# Altium schematic sheet B2B_Connector.SchDoc(B2B_Connector)
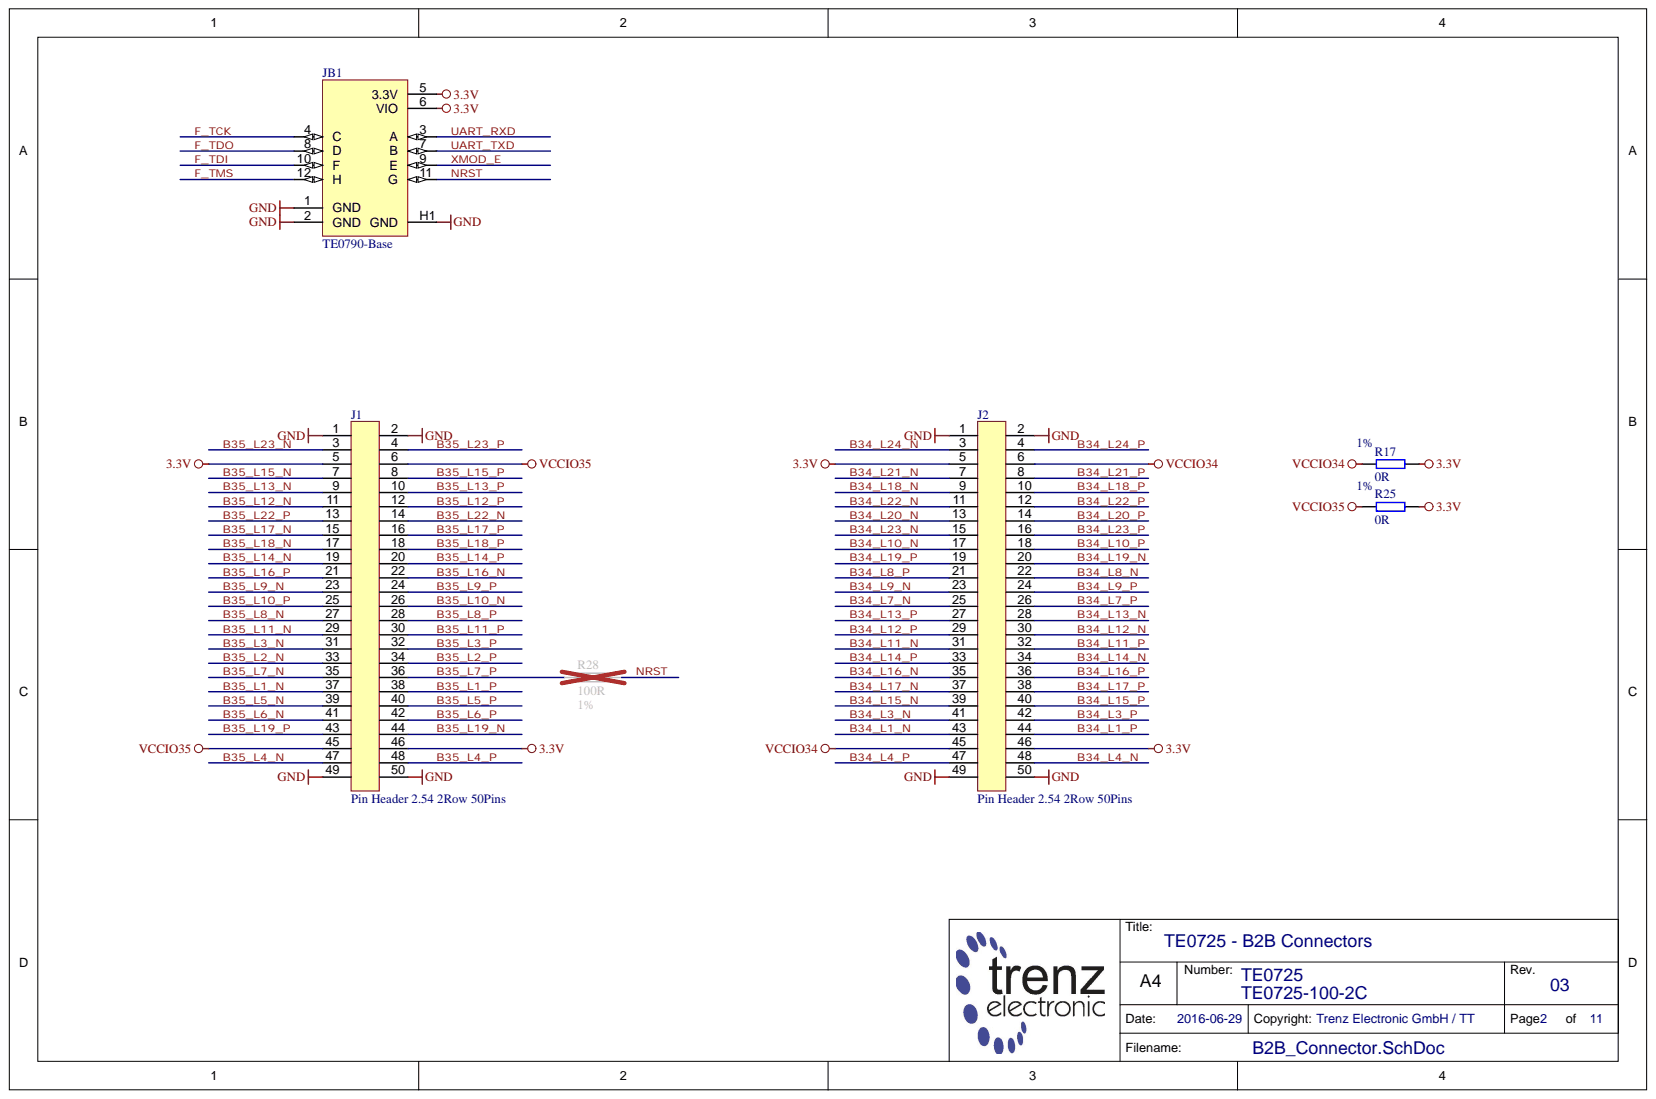
[diagram p0: B2B_Connector.SchDoc]

## Parts
J1  Pin Header 2.54 2Row 50Pins  [STIFTLEISTE2_54 OG 2R-50]
J2  Pin Header 2.54 2Row 50Pins  [STIFTLEISTE2_54 OG 2R-50]
JB1  TE0790-Base  [TE0790-Base]
R17  0R  Tolerance: 1%  [RESC1608X55N]
R25  0R  Tolerance: 1%  [RESC1608X55N]
R28  100R  Tolerance: 1%  [RESC1005X40N]

## Nets
3.3V: J1.5 J1.46 J2.5 J2.46 JB1.5(3.3V) JB1.6(VIO) R17.2 R25.2
GND: J1.1 J1.2 J1.49 J1.50 J2.1 J2.2 J2.49 J2.50 JB1.1(GND) JB1.2(GND) JB1.H1(GND)
VCCIO34: J2.6 J2.45 R17.1
VCCIO35: J1.6 J1.45 R25.1
B34_L1_N: J2.43
B34_L1_P: J2.44
B34_L3_N: J2.41
B34_L3_P: J2.42
B34_L4_N: J2.48
B34_L4_P: J2.47
B34_L7_N: J2.25
B34_L7_P: J2.26
B34_L8_N: J2.22
B34_L8_P: J2.21
B34_L9_N: J2.23
B34_L9_P: J2.24
B34_L10_N: J2.17
B34_L10_P: J2.18
B34_L11_N: J2.31
B34_L11_P: J2.32
B34_L12_N: J2.30
B34_L12_P: J2.29
B34_L13_N: J2.28
B34_L13_P: J2.27
B34_L14_N: J2.34
B34_L14_P: J2.33
B34_L15_N: J2.39
B34_L15_P: J2.40
B34_L16_N: J2.35
B34_L16_P: J2.36
B34_L17_N: J2.37
B34_L17_P: J2.38
B34_L18_N: J2.9
B34_L18_P: J2.10
B34_L19_N: J2.20
B34_L19_P: J2.19
B34_L20_N: J2.13
B34_L20_P: J2.14
B34_L21_N: J2.7
B34_L21_P: J2.8
B34_L22_N: J2.11
B34_L22_P: J2.12
B34_L23_N: J2.15
B34_L23_P: J2.16
B34_L24_N: J2.3
B34_L24_P: J2.4
B35_L1_N: J1.37
B35_L1_P: J1.38
B35_L2_N: J1.33
B35_L2_P: J1.34
B35_L3_N: J1.31
B35_L3_P: J1.32
B35_L4_N: J1.47
B35_L4_P: J1.48
B35_L5_N: J1.39
B35_L5_P: J1.40
B35_L6_N: J1.41
B35_L6_P: J1.42
B35_L7_N: J1.35
B35_L7_P: J1.36 R28.1
B35_L8_N: J1.27
B35_L8_P: J1.28
B35_L9_N: J1.23
B35_L9_P: J1.24
B35_L10_N: J1.26
B35_L10_P: J1.25
B35_L11_N: J1.29
B35_L11_P: J1.30
B35_L12_N: J1.11
B35_L12_P: J1.12
B35_L13_N: J1.9
B35_L13_P: J1.10
B35_L14_N: J1.19
B35_L14_P: J1.20
B35_L15_N: J1.7
B35_L15_P: J1.8
B35_L16_N: J1.22
B35_L16_P: J1.21
B35_L17_N: J1.15
B35_L17_P: J1.16
B35_L18_N: J1.17
B35_L18_P: J1.18
B35_L19_N: J1.44
B35_L19_P: J1.43
B35_L22_N: J1.14
B35_L22_P: J1.13
B35_L23_N: J1.3
B35_L23_P: J1.4
F_TCK: JB1.4(C)
F_TDI: JB1.10(F)
F_TDO: JB1.8(D)
F_TMS: JB1.12(H)
NRST: JB1.11(G) R28.2
UART_RXD: JB1.3(A)
UART_TXD: JB1.7(B)
XMOD_E: JB1.9(E)

## Library data of the parts
J1 J2 = 22403: Stiftleiste gerade 2-reihig, 50pol; Database Table Name: CON_HEADER; H-ART: 944-12-050-00; Mixed: THT; Trenz-Stock: Yes; AN: 22403; Component Kind: Standard; Library Name: TE.DbLib
JB1 = 26694: Database Table Name: BLOCKS; Mixed: THT; Trenz-Article-Number: 22495; AN: 26694; Component Kind: Standard; Description: TE0790-Base; Library Name: TE.DbLib
R17 R25 = 21491: WID SMD 0R 0,1W 1% TK100; Database Table Name: R_1608_0603; Mixed: SMT; Value: 0; AN: 21491; Case: 1608 (0603); Component Kind: Standard; Library Name: TE.DbLib; Technology: TK100
R28 = 22507: WID SMD 100R 1% 0,063W; Database Table Name: R_1005_0402; Mixed: SMT; Preferred: Yes; Value: 100; AN: 22507; Case: 1005 (0402); Component Kind: Standard; Library Name: TE.DbLib; Technology: TK100
